# Altium schematic sheet [04] - MICROCONTROLLER.SchDoc (continued)

## Library data of the parts
AE1 = 2450AT45A100E: RF ANT 2.4GHZ CHIP SOLDER SMD; Manufacturer 1: Johanson; .PCBChecked: Alex Batista; Bandwidth: 100MHz; Frequency: 2.45GHz; Gain: 1dB; Impedance: 50R; Max Frequency: 2.5GHz; Max Power Dissipation: 3W; Number of Bands: 1; Return Loss: -9.5dB; Component Kind: Standard; ComponentLink1Description: https://datasheet.ciiva.com/1126/1296113-1126176.pdf?src-supplier=Newark; ComponentLink1URL: https://datasheet.ciiva.com/1126/1296113-1126176.pdf?src-supplier=Newark; ComponentLink2Description: https://datasheet.ciiva.com/2791/jti-antenna-2450at45a100-2006-07-2791936.pdf?src-supplier=Digi-Key; ComponentLink2URL: https://datasheet.ciiva.com/2791/jti-antenna-2450at45a100-2006-07-2791936.pdf?src-supplier=Digi-Key; ComponentLink3Description: https://datasheet.ciiva.com/6748/antenna-2450at45a100-v5-6748578.pdf?src-supplier=Newark; ComponentLink3URL: https://datasheet.ciiva.com/6748/antenna-2450at45a100-v5-6748578.pdf?src-supplier=Newark; ComponentLink4Description: https://datasheet.ciiva.com/24068/antenna-2450at45a100-v5-242465-24068002.pdf?src-supplier=Mouser; ComponentLink4URL: https://datasheet.ciiva.com/24068/antenna-2450at45a100-v5-242465-24068002.pdf?src-supplier=Mouser; ComponentLink5Description: https://datasheet.ciiva.com/2791/jti-antenna-2450at45a100-2006-07-2791937.pdf?src-supplier=Digi-Key; ComponentLink5URL: https://datasheet.ciiva.com/2791/jti-antenna-2450at45a100-2006-07-2791937.pdf?src-supplier=Digi-Key; ComponentLink6Description: https://datasheet.ciiva.com/2791/jti-antenna-2450at45a100-2006-07-2791938.pdf?src-supplier=Digi-Key; ComponentLink6URL: https://datasheet.ciiva.com/2791/jti-antenna-2450at45a100-2006-07-2791938.pdf?src-supplier=Digi-Key; ComponentLink7Description: https://datasheet.ciiva.com/1124/1318299-1124920.pdf?src-supplier=Element14; ComponentLink7URL: https://datasheet.ciiva.com/1124/1318299-1124920.pdf?src-supplier=Element14; Height: 0.047inch; Length: 9.5mm; Manufacturer Part Number 1: 2450AT45A100E; Max Operating Temperature: 85°C; Min Operating Temperature: -40°C; Mount: Surface Mount; Number of Pins: 2; Package Quantity: 1; Packaging: Tape and Reel; Power Rating: 3W; Pricing 1: 1=0.94000, 10=0.84100, 25=0.79800, 100=0.65550, 250=0.61276, 500=0.54150 (USD); Radiation Hardening: No; REACH SVHC: No SVHC; Resistor Type: Ceramic; RoHS: Yes; Stock 1: 275; Supplier 1: Digi-Key; Supplier Part Number 1: 712-1012-1-ND; Termination: Solder; Width: 2mm; .SCHChecked: Alex Batista; Library Name: fast_charger_slide_controller_P2.SchLib
C7 C8 C16 C18 C26 C27 = KGM05AR71C104KH: MLCC - 100nF 16V 0402 X7R ±10%.; Manufacturer 1: Kyocera AVX; .Mounting: SMT; .PCBChecked: Lucas Vieira; Category 1: Ceramic Capacitors; Description 1: Cap Cer 0.1UF 16V X7R 0402; Component Kind: Standard; Manufacturer 2: Murata; Manufacturer 3: Taiyo Yuden; Manufacturer Part Number 1: KGM05AR71C104KH; Manufacturer Part Number 2: GRM155R71E104KE14J; Manufacturer Part Number 3: EMK105B7104KV-F; Pricing 1: 1=0,1, 10=0,014, 50=0,008, 100=0,0062, 500=0,00468, 1000=0,00368, 2500=0,00335, 5000=0,00308 (USD); RoHS 1: No; Stock 1: 2329199; Supplier 1: Digikey; Supplier Part Number 1: 478-KGM05AR71C104KHCT-ND; .SCHChecked: Lucas Vieira; Library Name: fast_charger_slide_controller_P2.SchLib
C9 C14 = CL05X105KP5NNNC: MLCC - 1µF 10V 0402 X6R ±10%.; Manufacturer 1: Samsung; .Mounting: SMT; Capacitor Type: Ceramic; Multilayer: Yes; Temperature Characteristics Code: X6S; Tolerance  (fill in as +-): 10%; Value: 1uF; Case/Package: 0402; Category: Ceramic Capacitors; Component Kind: Standard; ComponentLink1Description: https://datasheet.ciiva.com:443/pdfs/VipMasterIC/IC/SEMS/SEMS-S-A0008522793/SEMS-S-A0008522935-1.pdf?src-supplier=IHS+Markit; ComponentLink1URL: https://datasheet.ciiva.com:443/pdfs/VipMasterIC/IC/SEMS/SEMS-S-A0008522793/SEMS-S-A0008522935-1.pdf?src-supplier=IHS+Markit; ComponentLink2Description: http://www.samsungsem.com/kr/support/library/product-catalog/mlcc.jsp?src-supplier=Digikey; ComponentLink2URL: http://www.samsungsem.com/kr/support/library/product-catalog/mlcc.jsp?src-supplier=Digikey; ComponentLink3Description: http://www.samsungsem.com/kr/support/product-search/mlcc/CL05X105KP5NNNC.jsp?src-supplier=Digikey; ComponentLink3URL: http://www.samsungsem.com/kr/support/product-search/mlcc/CL05X105KP5NNNC.jsp?src-supplier=Digikey; ComponentLink4Description: http://www.samsungsem.com/global/front/downloadcms.do?path=%2F%2Fglobal%2F%2Fsupport%2F%2Flibrary%2F%2Fproduct-catalog%2F%2F__icsFiles%2F%2Fafieldfile%2F%2F2014%2F%2F11%2F%2F13&fileName=MLCC.pdf&src-supplier=Avnet; ComponentLink4URL: http://www.samsungsem.com/global/front/downloadcms.do?path=%2F%2Fglobal%2F%2Fsupport%2F%2Flibrary%2F%2Fproduct-catalog%2F%2F__icsFiles%2F%2Fafieldfile%2F%2F2014%2F%2F11%2F%2F13&fileName=MLCC.pdf&src-supplier=Avnet; ComponentLink5Description: https://datasheet.octopart.com/CL05X105KP5NNNC-Samsung-Electro-Mechanics-datasheet-115064993.pdf?src-supplier=Future+Electronics; ComponentLink5URL: https://datasheet.octopart.com/CL05X105KP5NNNC-Samsung-Electro-Mechanics-datasheet-115064993.pdf?src-supplier=Future+Electronics; Dielectric Material: Ceramic; Height: 0.55mm; JESD-609 Code: e3; Length: 1mm; Manufacturer Part Number 1: CL05X105KP5NNNC; Max Operating Temperature: 105°C; Min Operating Temperature: -55°C; Mounting Technology: Surface Mount; Package Shape: Rectangular; Package Style: SMTMeter; Packaging: Tape and Reel; Pins: 2; Pricing: 10000=0,00801 (USD); Rated DC Voltage (URdc): 10V; Reach SVHC Compliant: Yes; RoHS: Yes; RoHS Compliant: Yes; Stock: 10000; Supplier 1: Avnet; Supplier Part Number 1: CL05X105KP5NNNC; Terminal Finish: Nickel; Termination: Wraparound; Tolerance (fill in as +-): 10%; Width: 0.5mm; Library Name: fast_charger_slide_controller_P2.SchLib
C10 = GRM155R71H473KE14D: MLCC - 47nF 50V 0402 X7R ±10%; Manufacturer 1: Murata; .Mounting: SMT; Capacitor Type: Ceramic; Multilayer: Yes; Case/Package: 0402; Category: Ceramic Capacitors; Component Kind: Standard; ComponentLink1Description: https://datasheet.ciiva.com:443/pdfs/VipMasterIC/IC/MURE/MURE-S-A0004362469/MURE-S-A0004364796-1.pdf?src-supplier=IHS+Markit; ComponentLink1URL: https://datasheet.ciiva.com:443/pdfs/VipMasterIC/IC/MURE/MURE-S-A0004362469/MURE-S-A0004364796-1.pdf?src-supplier=IHS+Markit; ComponentLink2Description: http://www.mouser.com/ds/2/281/c02e-2905.pdf?src-supplier=Mouser; ComponentLink2URL: http://www.mouser.com/ds/2/281/c02e-2905.pdf?src-supplier=Mouser; ComponentLink3Description: http://search.murata.co.jp/Ceramy/image/img/A01X/G101/ENG/GRM155R71H473KE14-01.pdf?src-supplier=Digikey; ComponentLink3URL: http://search.murata.co.jp/Ceramy/image/img/A01X/G101/ENG/GRM155R71H473KE14-01.pdf?src-supplier=Digikey; ComponentLink4Description: https://datasheet.octopart.com/GRM155R71H473KE14D-Murata-datasheet-32479577.pdf?src-supplier=Future+Electronics; ComponentLink4URL: https://datasheet.octopart.com/GRM155R71H473KE14D-Murata-datasheet-32479577.pdf?src-supplier=Future+Electronics; ComponentLink5Description: https://datasheet.octopart.com/GRM155R71H473KE14D-Murata-datasheet-149523079.pdf?src-supplier=Newark; ComponentLink5URL: https://datasheet.octopart.com/GRM155R71H473KE14D-Murata-datasheet-149523079.pdf?src-supplier=Newark; ComponentLink6Description: https://datasheet.octopart.com/GRM155R71H473KE14D-Murata-datasheet-150009149.pdf?src-supplier=Arrow.cn; ComponentLink6URL: https://datasheet.octopart.com/GRM155R71H473KE14D-Murata-datasheet-150009149.pdf?src-supplier=Arrow.cn; ComponentLink7Description: https://datasheet.octopart.com/GRM155R71H473KE14D-Murata-datasheet-165579666.pdf?src-supplier=TME; ComponentLink7URL: https://datasheet.octopart.com/GRM155R71H473KE14D-Murata-datasheet-165579666.pdf?src-supplier=TME; Dielectric Material: Ceramic; Height: 0.5mm; JESD-609 Code: e3; Length: 1mm; Manufacturer Part Number 1: GRM155R71H473KE14D; Max Operating Temperature: 125°C; Min Operating Temperature: -55°C; Mounting Technology: Surface Mount; Package Shape: Rectangular; Package Style: SMTMeter; Packaging: Tape and Reel; Pins: 2; Pricing: 10000=0,0031, 40000=0,0029, 150000=0,0028, 250000=0,0027, 500000=0,0025 (USD); Rated DC Voltage (URdc): 50V; Reach SVHC Compliant: Yes; RoHS: Yes; RoHS Compliant: Yes; Stock: 660000; Supplier 1: Future Electronics; Supplier Part Number 1: 7087193; Temperature Characteristics Code: X7R; Terminal Finish: Nickel; Termination: Wraparound; Tolerance  (fill in as +-): 10%; Tolerance (fill in as +-): 10%; Value: 47nF; Width: 0.5mm; Library Name: fast_charger_slide_controller_P2.SchLib
C11 = MLCC_0402: MLCC - TBD 0402.; Component Kind: Standard
C12 C24 = GRM21BR71C475KE51K: MLCC - 4.7µF 16V 0805 X7R ±10%.; Manufacturer 1: Murata; .Mounting: SMT; .PCBChecked: Lucas Vieira; Category 1: Ceramic Capacitors; Description 1: Cap 4.7UF 16VDC X7R 10% SMD 0805 Embossed Tape; Tolerance: 10%; Value: 4.7uF; Case/Package: 0805; Component Kind: Standard; ComponentLink1Description: https://search.murata.co.jp/Ceramy/image/img/A01X/G101/ENG/GRM21BR71C475KE51-01A.pdf?src-supplier=Digi-Key; ComponentLink1URL: https://search.murata.co.jp/Ceramy/image/img/A01X/G101/ENG/GRM21BR71C475KE51-01A.pdf?src-supplier=Digi-Key; Dielectric: X7R; Length: 2mm; Manufacturer 2: Murata; Manufacturer 3: Murata; Manufacturer Part Number 1: GRM21BR71C475KE51K; Manufacturer Part Number 2: GRM21BR71A475KE51K; Manufacturer Part Number 3: GRM21BR71A475KE51L; Pricing 1: 1=0,15, 10=0,109, 50=0,0616, 100=0,0511, 500=0,0358, 1000=0,02964, 2500=0,02759, 5000=0,02524 (USD); RoHS 1: Yes; RoHS Compliant: Yes; Stock 1: 90687; Supplier 1: Digi-Key; Supplier Part Number 1: 490-GRM21BR71C475KE51KCT-ND; Thickness: 1.25mm; Voltage Rating (DC): 16V; Width: 1.25mm; .SCHChecked: Lucas Vieira; Library Name: fast_charger_slide_controller_P2.SchLib
C13 = CC0402JRX7R9BB821: MLCC - 820pF 50V 0402 X7R ±5%; Manufacturer 1: Yageo; .Mounting: SMT; Capacitor Type: Ceramic; Multilayer: Yes; Case/Package: 0402; Category: Ceramic Capacitors; Component Kind: Standard; ComponentLink1Description: https://datasheet.ciiva.com:443/pdfs/VipMasterIC/IC/YAGO/YAGO-S-A0003557223/YAGO-S-A0003557223-1.pdf?src-supplier=IHS+Markit; ComponentLink1URL: https://datasheet.ciiva.com:443/pdfs/VipMasterIC/IC/YAGO/YAGO-S-A0003557223/YAGO-S-A0003557223-1.pdf?src-supplier=IHS+Markit; ComponentLink2Description: https://datasheet.ciiva.com/13263/upy-gphc-x7r-6-3v-to-50v-8-12304-13263692.pdf?src-supplier=Mouser; ComponentLink2URL: https://datasheet.ciiva.com/13263/upy-gphc-x7r-6-3v-to-50v-8-12304-13263692.pdf?src-supplier=Mouser; Dielectric Material: Ceramic; JESD-609 Code: e3; Manufacturer Part Number 1: CC0402JRX7R9BB821; Max Operating Temperature: 125°C; Min Operating Temperature: -55°C; Mounting Technology: Surface Mount; Package Shape: Rectangular; Packaging: Tape and Reel; Pins: 2; Pricing: 1=0,1, 10=0,037, 50=0,021, 100=0,0162, 500=0,01224, 1000=0,00961, 2500=0,00874, 5000=0,00804 (USD); Rated DC Voltage (URdc): 50V; Reach SVHC Compliant: Yes; RoHS: Yes; RoHS Compliant: Yes; Stock: 106880; Supplier 1: Digikey; Supplier Part Number 1: 311-3776-1-ND; Temperature Characteristics Code: X7R; Terminal Finish: Nickel; Termination: Wraparound; Tolerance  (fill in as +-): 5%; Tolerance (fill in as +-): 5%; Value: 820pF; Library Name: fast_charger_slide_controller_P2.SchLib
C15 C17 C19 C21 = CC0402JRNPO9BN120: MLCC - 12 pF 50V 0402 X7R ±5%.; Manufacturer 1: Yageo; .Mounting: SMT; .PCBChecked: Lucas Vieira; Category 1: Ceramic Capacitors; Description 1: Multilayer Ceramic Capacitor, 12 pF, 50 V, ± 5%, X7R, 0402 [1005 Metric]; Dielectric: C0G; Material: 4241ConductorMaterial; Temperature Coefficient: 30ppm/°C; Tolerance: 5%; Value: 12pF; Case Code (Imperial): 0402; Case Code (Metric): 1005; Case/Package: 0402; Component Kind: Standard; ComponentLink1Description: https://datasheet.ciiva.com/161/mlccorderingcode-161486.pdf?src-supplier=Digikey; ComponentLink1URL: https://datasheet.ciiva.com/161/mlccorderingcode-161486.pdf?src-supplier=Digikey; ComponentLink2Description: https://datasheet.ciiva.com/28450/1443161-28450222.pdf?src-supplier=Element14; ComponentLink2URL: https://datasheet.ciiva.com/28450/1443161-28450222.pdf?src-supplier=Element14; ComponentLink3Description: https://datasheet.ciiva.com/5425/upy-gp-np0-16v-to-50v-8-5425431.pdf?src-supplier=Mouser; ComponentLink3URL: https://datasheet.ciiva.com/5425/upy-gp-np0-16v-to-50v-8-5425431.pdf?src-supplier=Mouser; ComponentLink4Description: https://datasheet.ciiva.com/161/mlccorderingcode-161487.pdf?src-supplier=Digikey; ComponentLink4URL: https://datasheet.ciiva.com/161/mlccorderingcode-161487.pdf?src-supplier=Digikey; ComponentLink5Description: https://datasheet.ciiva.com/161/mlccorderingcode-161488.pdf?src-supplier=Digikey; ComponentLink5URL: https://datasheet.ciiva.com/161/mlccorderingcode-161488.pdf?src-supplier=Digikey; ComponentLink6Description: https://datasheet.ciiva.com/11587/upy-gp-np0-16v-to-50v-8-11587083.pdf?src-supplier=Avnet; ComponentLink6URL: https://datasheet.ciiva.com/11587/upy-gp-np0-16v-to-50v-8-11587083.pdf?src-supplier=Avnet; Depth: 0.5mm; Halogen Free: 5694HalogenFree; Height: 0.5mm; Lead Free: 5697LeadFree; Length: 1mm; Manufacturer 2: KYOCERA AVX; Manufacturer 3: KYOCERA AVX; Manufacturer Part Number 1: CC0402JRNPO9BN120; Manufacturer Part Number 2: KGM05ACG1H120JN; Manufacturer Part Number 3: KGM05ACG1H120JH; Max Operating Temperature: 125°C; Min Operating Temperature: -55°C; Mounting Technology: Surface Mount; Package Quantity: 1; Packaging: Tape and Reel; Pins: 2; Pricing 1: 1=0,1, 10=0,017, 50=0,01, 100=0,0077, 500=0,00584, 1000=0,00458, 2500=0,00417, 5000=0,00383 (USD); Radiation Hardening: No; Reach SVHC Compliant: No; RoHS 1: Yes; RoHS Compliant: Yes; Series: CC; Stock 1: 2131688; Supplier 1: Digikey; Supplier Part Number 1: 311-1016-1-ND; Termination: SMD/SMT; Thickness: 0.022inch; Voltage Rating: 50V; Voltage Rating (DC): 50V; Width: 0.02inch; .SCHChecked: Lucas Vieira; Library Name: fast_charger_slide_controller_P2.SchLib
C20 = CC0402JRNPO9BN101: MLCC - 100pF 50V 0402 NP0 ±5%.; Manufacturer 1: Yageo; .Mounting: SMT; .PCBChecked: Lucas Vieira; Category 1: Ceramic Capacitors; Description 1: 0402 100 pF 50 V ±5 % Tolerance NP0 SMT Multilayer Ceramic Capacitor; Dielectric: C0G; Dielectric Material: Ceramic; Insulation Resistance: 100GR; Temperature Coefficient: 30ppm/°C; Tolerance: 5%; Case Code (Imperial): 0402; Case Code (Metric): 1005; Case/Package: 0402; Component Kind: Standard; ComponentLink1Description: https://datasheet.ciiva.com/11786/0900766b80d84fbf-11786101.pdf?src-supplier=Rs; ComponentLink1URL: https://datasheet.ciiva.com/11786/0900766b80d84fbf-11786101.pdf?src-supplier=Rs; ComponentLink2Description: https://datasheet.ciiva.com/23767/25787-23767888.pdf?src-supplier=Newark; ComponentLink2URL: https://datasheet.ciiva.com/23767/25787-23767888.pdf?src-supplier=Newark; ComponentLink3Description: https://datasheet.ciiva.com/28450/1443161-28450220.pdf?src-supplier=Element14; ComponentLink3URL: https://datasheet.ciiva.com/28450/1443161-28450220.pdf?src-supplier=Element14; ComponentLink4Description: https://datasheet.ciiva.com/8033/1443161-8033598.pdf?src-supplier=Element14; ComponentLink4URL: https://datasheet.ciiva.com/8033/1443161-8033598.pdf?src-supplier=Element14; ComponentLink5Description: https://datasheet.ciiva.com/143/mlccorderingcode-143990.pdf?src-supplier=Digikey; ComponentLink5URL: https://datasheet.ciiva.com/143/mlccorderingcode-143990.pdf?src-supplier=Digikey; ComponentLink6Description: https://datasheet.ciiva.com/143/mlccorderingcode-143989.pdf?src-supplier=Digikey; ComponentLink6URL: https://datasheet.ciiva.com/143/mlccorderingcode-143989.pdf?src-supplier=Digikey; ComponentLink7Description: https://datasheet.ciiva.com/143/mlccorderingcode-143991.pdf?src-supplier=Digikey; ComponentLink7URL: https://datasheet.ciiva.com/143/mlccorderingcode-143991.pdf?src-supplier=Digikey; Depth: 0.5mm; Height: 0.5mm; Lead Free: Lead Free; Length: 1mm; Manufacturer 2: Murata; Manufacturer 3: Murata; Manufacturer Part Number 1: CC0402JRNPO9BN101; Manufacturer Part Number 2: GRM1555C1H101JA01D; Manufacturer Part Number 3: GCM1555C1H101JA16D; Max Operating Temperature: 125°C; Min Operating Temperature: -55°C; Mounting Technology: Surface Mount; Package Quantity: 1; Packaging: Tape and Reel; Pins: 2; Pricing 1: 1=0,1, 10=0,017, 50=0,0096, 100=0,0074, 500=0,00554, 1000=0,00436, 2500=0,00396, 5000=0,00365 (USD); Radiation Hardening: No; Reach SVHC Compliant: No; RoHS 1: Yes; RoHS Compliant: Yes; Series: CC; Stock 1: 10509796; Supplier 1: Digikey; Supplier Part Number 1: 311-1024-1-ND; Termination: SMD/SMT; Thickness: 0.022inch; Value: 100pF; Voltage: 50V; Voltage Rating: 50V; Voltage Rating (DC): 50V; Width: 0.02inch; .SCHChecked: Lucas Vieira; Library Name: fast_charger_slide_controller_P2.SchLib
C22 = GJM1555C1H1R0WB01D: MLCC - 1pF 50V 0402 NP0 ±5%.; Manufacturer 1: Murata; .Mounting: SMT; Capacitor Type: Ceramic; Multilayer: Yes; Temperature Characteristics Code: C0G; Tolerance  (fill in as +-): 5%; Case/Package: 0402; Category: Ceramic Capacitors; Component Kind: Standard; ComponentLink1Description: https://datasheet.ciiva.com:443/pdfs/VipMasterIC/IC/MURE/MURE-S-A0004378008/MURE-S-A0004383032-1.pdf?src-supplier=IHS+Markit; ComponentLink1URL: https://datasheet.ciiva.com:443/pdfs/VipMasterIC/IC/MURE/MURE-S-A0004378008/MURE-S-A0004383032-1.pdf?src-supplier=IHS+Markit; ComponentLink2Description: https://datasheet.ciiva.com/11616/product-11616304.pdf?src-supplier=Avnet; ComponentLink2URL: https://datasheet.ciiva.com/11616/product-11616304.pdf?src-supplier=Avnet; Dielectric Material: Ceramic; Height: 0.5mm; JESD-609 Code: e3; Length: 1mm; Manufacturer Part Number 1: GJM1555C1H1R0WB01D; Max Operating Temperature: 125°C; Min Operating Temperature: -55°C; Mounting Technology: Surface Mount; Package Shape: Rectangular; Package Style: SMTMeter; Packaging: Tape and Reel; Pins: 2; Pricing: 10000=0,0193, 20000=0,0189, 40000=0,0185, 50000=0,0183, 150000=0,0175 (USD); Rated DC Voltage (URdc): 50V; Reach SVHC Compliant: Yes; RoHS: Yes; RoHS Compliant: Yes; Stock: 100000; Supplier 1: Future Electronics; Supplier Part Number 1: 9074054; Terminal Finish: Nickel; Termination: Wraparound; Tolerance (fill in as +-): 5%; Value: 1pF; Width: 0.5mm; Library Name: fast_charger_slide_controller_P2.SchLib
C23 = GJM1555C1H1R2WB01J: MLCC - 1.2pF 50V 0402 NP0 ±4.17%; Manufacturer 1: Murata; .Mounting: SMT; Capacitor Type: Ceramic; Multilayer: Yes; Temperature Characteristics Code: C0G; Case/Package: 0402; Category: Ceramic Capacitors; Component Kind: Standard; ComponentLink1Description: https://datasheet.ciiva.com:443/pdfs/VipMasterIC/IC/MURE/MURE-S-A0004378008/MURE-S-A0004382814-1.pdf?src-supplier=IHS+Markit; ComponentLink1URL: https://datasheet.ciiva.com:443/pdfs/VipMasterIC/IC/MURE/MURE-S-A0004378008/MURE-S-A0004382814-1.pdf?src-supplier=IHS+Markit; ComponentLink2Description: http://www.mouser.com/ds/2/281/Murata%20GJM%20Series-1149669.pdf?src-supplier=Mouser; ComponentLink2URL: http://www.mouser.com/ds/2/281/Murata%20GJM%20Series-1149669.pdf?src-supplier=Mouser; ComponentLink3Description: https://datasheet.octopart.com/GJM1555C1H1R2WB01J-Murata-datasheet-155238911.pdf?src-supplier=Farnell; ComponentLink3URL: https://datasheet.octopart.com/GJM1555C1H1R2WB01J-Murata-datasheet-155238911.pdf?src-supplier=Farnell; ComponentLink4Description: http://search.murata.co.jp/Ceramy/image/img/PDF/ENG/GJM1555C1H1R2WB01.pdf?src-supplier=Avnet; ComponentLink4URL: http://search.murata.co.jp/Ceramy/image/img/PDF/ENG/GJM1555C1H1R2WB01.pdf?src-supplier=Avnet; Dielectric Material: Ceramic; Height: 0.5mm; JESD-609 Code: e3; Length: 1mm; Manufacturer Part Number 1: GJM1555C1H1R2WB01J; Max Operating Temperature: 125°C; Min Operating Temperature: -55°C; Mounting Technology: Surface Mount; Package Shape: Rectangular; Package Style: SMTMeter; Packaging: Tape and Reel; Pins: 2; Pricing: 1=0,17, 10=0,073, 50=0,073, 100=0,043, 1000=0,032, 10000=0,025 (USD); Rated DC Voltage (URdc): 50V; Reach SVHC Compliant: Yes; RoHS: Yes; RoHS Compliant: Yes; Stock: 18280; Supplier 1: Mouser; Supplier Part Number 1: 81-GJM1555C1H1R2WB1J; Terminal Finish: Nickel; Termination: Wraparound; Tolerance  (fill in as +-): 4.17%; Tolerance (fill in as +-): 4.17%; Value: 1.2pF; Width: 0.5mm; Library Name: fast_charger_slide_controller_P2.SchLib
D3 = KW DELPS2.RA-MIPI-FK1NN1-S4W5-0.5-R18: DIODE - LED WHITE DIFFUSED 2.625V 0.5mA; Manufacturer 1: ams-osram; .Mounting: SMT; Category: LEDS; Component Kind: Standard; Manufacturer Part Number 1: KW DELPS2.RA-MIPI-FK1NN1-S4W5-0.5-R18; Supplier: Digikey; Library Name: fast_charger_slide_controller_P2.SchLib
L1 = BSCH0010050515NJCS: IND - 15nH 100MHz 300mA 0402 ±5%.; Manufacturer 1: Pulse; .Mounting: SMT; .PCBChecked: Lucas Vieira; Category 1: Fixed Inductors; Description 1: Inductor Chip Unshielded Multilayer 15nH 5% 100MHz 8Q-Factor Ceramic 300mA 0402 T/R; Component Kind: Standard; Manufacturer 2: Pulse; Manufacturer 3: Pulse; Manufacturer Part Number 1: BSCH0010050515NJCS; Manufacturer Part Number 2: BSCH0010050515NKCS; Manufacturer Part Number 3: BSCH0010050515NJCP; Pricing 1: 1=0,1, 10=0,028, 25=0,0268, 50=0,0226, 100=0,0192, 250=0,01832, 500=0,01666, 1000=0,014, 2500=0,012 (USD); RoHS 1: No; Stock 1: 175439; Supplier 1: Digikey; Supplier Part Number 1: 553-BSCH0010050515NJCSCT-ND; .SCHChecked: Lucas Vieira; Library Name: fast_charger_slide_controller_P2.SchLib
L2 = IND_0402: IND - TBD 0402.; Component Kind: Standard; Library Name: fast_charger_slide_controller.SchLib
L3 = MLZ1608M100WT000: IND - 10µH 2MHz 0.09A 1.365Ohm 0603 ±20%.; Manufacturer 1: TDK; .Mounting: SMT; .PCBChecked: Lucas Vieira; Category 1: Fixed Inductors; Core Material: Ferrite; Current Rating: 250mA; DC Resistance (DCR): 1.365R; Description 1: Inductor Power Shielded Multi-Layer 10uH 20% 2MHz Ferrite 0.09A 1.365Ohm DCR 0603 T/R; ESR: 1.05R; Saturation Current: 90mA; Self Resonant Frequency: 50MHz; Shielding: Shielded; Tolerance: 20%; Value: 1.05R; Case Code (Imperial): 0603; Case Code (Metric): 1608; Case/Package: 0603; Component Kind: Standard; ComponentLink1Description: https://product.tdk.com/info/en/catalog/datasheets/inductor_commercial_decoupling_mlz1608_en.pdf?src-supplier=Digikey; ComponentLink1URL: https://product.tdk.com/info/en/catalog/datasheets/inductor_commercial_decoupling_mlz1608_en.pdf?src-supplier=Digikey; ComponentLink2Description: https://datasheet.octopart.com/MLZ1608M100WT000-TDK-datasheet-12356712.pdf?src-supplier=Newark; ComponentLink2URL: https://datasheet.octopart.com/MLZ1608M100WT000-TDK-datasheet-12356712.pdf?src-supplier=Newark; ComponentLink3Description: https://datasheet.octopart.com/MLZ1608M100WT000-TDK-datasheet-38159369.pdf?src-supplier=Future+Electronics; ComponentLink3URL: https://datasheet.octopart.com/MLZ1608M100WT000-TDK-datasheet-38159369.pdf?src-supplier=Future+Electronics; Height: 950um; Height - Seated (Max): 0.037inch; Length: 0.063inch; Manufacturer 2: TDK; Manufacturer 3: Murata; Manufacturer Part Number 1: MLZ1608M100WT000; Manufacturer Part Number 2: MLZ1608N100LT000; Manufacturer Part Number 3: LQM18DN100M70L; Max Operating Temperature: 125°C; Min Operating Temperature: -55°C; Mounting Technology: Surface Mount; Package Quantity: 4000; Packaging: Cut Tape; Pricing 1: 1=0,14, 10=0,114, 25=0,1052, 50=0,0928, 100=0,0811, 250=0,07724, 500=0,07262, 1000=0,05562 (USD); RMS Current (Irms): 250mA; RoHS 1: Yes; RoHS Compliant: Yes; Series: MLZ; Stock 1: 315981; Supplier 1: Digikey; Supplier Part Number 1: 445-6389-1-ND; Test Frequency: 2MHz; Width: 0.031inch; .SCHChecked: Lucas Vieira; Library Name: fast_charger_slide_controller_P2.SchLib
L4 = LRC0402CS4N7GV001T: IND - 4.7nH 100MHz 300mA 0402 6.38%; Manufacturer 1: Johanson; .Mounting: SMT; Category: Fixed Inductors; Component Kind: Standard; ComponentLink1Description: https://datasheet.octopart.com/LRC0402CS4N7GV001T-Johanson-datasheet-98397494.pdf?src-supplier=RFMW; ComponentLink1URL: https://datasheet.octopart.com/LRC0402CS4N7GV001T-Johanson-datasheet-98397494.pdf?src-supplier=RFMW; Manufacturer Part Number 1: LRC0402CS4N7GV001T; Pricing: 1=0,1, 10=0,041, 25=0,0384, 50=0,0326, 100=0,0276, 250=0,0264, 500=0,02398, 1000=0,02015, 2500=0,01727 (USD); Reach SVHC Compliant: No; RoHS: Yes; RoHS Compliant: Yes; Stock: 127873; Supplier 1: Digikey; Supplier Part Number 1: 712-LRC0402CS4N7GV001TCT-ND; Library Name: fast_charger_slide_controller_P2.SchLib
L5 = MLG1005S2N2BT000: IND - 2.2nH 100MHz 900mA 0402 4.5%; Manufacturer 1: TDK; .Mounting: SMT; Case/Size Code: 1005; Channels: 1; Core Material: Ferrite; DC Resistance (DCR): 150mR; Inductance-Nom (L): 0.0022uH; Inductor Application: RF INDUCTOR; Inductor Type: GENERAL PURPOSE INDUCTOR; Package Height: 0.5mm; Package Length: 1mm; Quality Factor-Min (at L-nom): 7; Self Resonant Frequency: 7GHz; Shape/Size Description: RECTANGULAR PACKAGE; Shielding: Unshielded; Tolerance: 4.546%; Category: Fixed Inductors; Component Kind: Standard; ComponentLink1Description: https://datasheet.ciiva.com:443/pdfs/VipMasterIC/IC/TDKC/TDKC-S-A0007110064/TDKC-S-A0007110064-1.pdf?src-supplier=IHS+Markit; ComponentLink1URL: https://datasheet.ciiva.com:443/pdfs/VipMasterIC/IC/TDKC/TDKC-S-A0007110064/TDKC-S-A0007110064-1.pdf?src-supplier=IHS+Markit; ComponentLink2Description: https://datasheet.ciiva.com/29696/inductor-commercial-high-frequency-mlg-en-29696230.pdf?src-supplier=Digikey; ComponentLink2URL: https://datasheet.ciiva.com/29696/inductor-commercial-high-frequency-mlg-en-29696230.pdf?src-supplier=Digikey; ComponentLink3Description: https://datasheet.ciiva.com/29696/inductor-commercial-high-frequency-mlg-en-29696231.pdf?src-supplier=Digikey; ComponentLink3URL: https://datasheet.ciiva.com/29696/inductor-commercial-high-frequency-mlg-en-29696231.pdf?src-supplier=Digikey; Construction: Multilayer Chip; JESD-609 Code: e3; Manufacturer Part Number 1: MLG1005S2N2BT000; Max Current Rating: 900mA; Max Operating Temperature: 125°C; Min Operating Temperature: -55°C; Package Style: SMTMeter; Package Width: 0.5mm; Packaging: Tape and Reel; Pins: 2; Pricing: 10000=0,0372, 20000=0,0363, 30000=0,0357, 40000=0,0354, 50000=0,0343 (USD); Reach SVHC Compliant: Yes; RoHS: Yes; RoHS Compliant: Yes; Series: MLG1005S; Stock: 10000; Supplier 1: Future Electronics; Supplier Part Number 1: 5073984; Surface Mount: Yes; Terminal Finish: Tin; Terminal Placement: Dual Ended; Termination: Wraparound; Test Frequency: 100MHz; Library Name: fast_charger_slide_controller_P2.SchLib
P2 = TC2030-CTX-NL: 6 pin Tag-Connect; .PCBChecked: Alex Batista; .SCHChecked: Alex Batista; Library Name: fast_charger_slide_controller.SchLib
Q2 = 2N7002K-7: TRANS - MOSFET N-Channel 60V 0.3A SOT-23.; Manufacturer 1: Diodes; .Mounting: SMT; Additional Feature: HIGH RELIABILITY; Drain Current-Max (Abs) (ID): 300mA; Drain-source On Resistance-Max: 2R; Feedback Cap-Max (Crss): 5pF; FET Technology: METAL-OXIDE SEMICONDUCTOR; Number of Elements: 1; Operating Mode: ENHANCEMENT MODE; Package Body Material: Plastic; Power Dissipation-Max (Abs): 350mW; Qualification Status: Not Qualified; Time @ Peak Reflow Temperature-Max (s): 40s; Transistor Application: SWITCHING; Transistor Element Material: SILICON; Category: MOSFETs; Component Kind: Standard; ComponentLink1Description: https://datasheet.ciiva.com:443/pdfs/VipMasterIC/IC/DIOD/DIOD-S-A0009689736/DIOD-S-A0009691901-1.pdf?src-supplier=IHS+Markit; ComponentLink1URL: https://datasheet.ciiva.com:443/pdfs/VipMasterIC/IC/DIOD/DIOD-S-A0009689736/DIOD-S-A0009691901-1.pdf?src-supplier=IHS+Markit; ComponentLink2Description: https://datasheet.ciiva.com/1254/ds30896-1254496.pdf?src-supplier=Newark; ComponentLink2URL: https://datasheet.ciiva.com/1254/ds30896-1254496.pdf?src-supplier=Newark; ComponentLink3Description: https://datasheet.ciiva.com/25624/0900766b81151e8c-25624936.pdf?src-supplier=Rs; ComponentLink3URL: https://datasheet.ciiva.com/25624/0900766b81151e8c-25624936.pdf?src-supplier=Rs; ComponentLink4Description: https://datasheet.ciiva.com/4444/ds30896-81375-4444887.pdf?src-supplier=Mouser; ComponentLink4URL: https://datasheet.ciiva.com/4444/ds30896-81375-4444887.pdf?src-supplier=Mouser; ComponentLink5Description: https://datasheet.ciiva.com/15137/getdatasheetpartid-75159-15137378.pdf?src-supplier=Verical; ComponentLink5URL: https://datasheet.ciiva.com/15137/getdatasheetpartid-75159-15137378.pdf?src-supplier=Verical; ComponentLink6Description: https://datasheet.ciiva.com/48532/ds30896-48532645.pdf?src-supplier=Avnet; ComponentLink6URL: https://datasheet.ciiva.com/48532/ds30896-48532645.pdf?src-supplier=Avnet; ComponentLink7Description: https://datasheet.ciiva.com/1188/ds30896-1188422.pdf?src-supplier=Element14; ComponentLink7URL: https://datasheet.ciiva.com/1188/ds30896-1188422.pdf?src-supplier=Element14; ComponentLink8Description: https://datasheet.ciiva.com/16200/ds30896-16200842.pdf?src-supplier=Future+Electronics; ComponentLink8URL: https://datasheet.ciiva.com/16200/ds30896-16200842.pdf?src-supplier=Future+Electronics; Drain Current-Max (ID): 300mA; DS Breakdown Voltage-Min: 60V; JESD-30 Code: R-PDSO-G3; JESD-609 Code: e3; Manufacturer Part Number 1: 2N7002K-7; Max Operating Temperature: 150°C; Moisture Sensitivity Level: 1; Package Shape: Rectangular; Package Style: SMALL OUTLINEMeter; Peak Reflow Temperature (Cel): 260°C; Pins: 3; Polarity/Channel Type: N-CHANNEL; Pricing: 3000=0,0186, 9000=0,0179, 30000=0,0172, 60000=0,0168, 120000=0,0161 (USD); Reach SVHC Compliant: Yes; RoHS: Yes; RoHS Compliant: Yes; Stock: 2232000; Supplier 1: Future Electronics; Supplier Part Number 1: 8672685; Surface Mount: Yes; Terminal Finish: Tin; Terminal Form: Gull Wing; Terminal Position: DUAL; Library Name: fast_charger_slide_controller_P2.SchLib
R7 R10 R12 = WR04X000PTL: RES - 0R  1/16W 0402 ±1%; Manufacturer 1: Walsin Technologies; .Mounting: SMT; Package Height: 0.35mm; Package Length: 1mm; Package Width: 0.5mm; Power: 62.5mW; Rated Temperature: 70°C; Case/Package: 0402; Category: Chip SMD Resistors; Component Kind: Standard; ComponentLink1Description: https://datasheet.ciiva.com:443/pdfs/VipMasterIC/IC/WATC/WATC-S-A0005256878/WATC-S-A0005256878-1.pdf?src-supplier=IHS+Markit; ComponentLink1URL: https://datasheet.ciiva.com:443/pdfs/VipMasterIC/IC/WATC/WATC-S-A0005256878/WATC-S-A0005256878-1.pdf?src-supplier=IHS+Markit; ComponentLink2Description: http://www.farnell.com/datasheets/1960319.pdf?src-supplier=Farnell; ComponentLink2URL: http://www.farnell.com/datasheets/1960319.pdf?src-supplier=Farnell; ComponentLink3Description: http://www.mouser.com/ds/2/210/WR-966.pdf?src-supplier=Mouser; ComponentLink3URL: http://www.mouser.com/ds/2/210/WR-966.pdf?src-supplier=Mouser; ComponentLink4Description: https://www.verical.com/server-webapp/html/getDatasheet?partID=413934&src-supplier=Verical; ComponentLink4URL: https://www.verical.com/server-webapp/html/getDatasheet?partID=413934&src-supplier=Verical; ComponentLink5Description: http://www.farnell.com/datasheets/1960319.pdf?src-supplier=Newark; ComponentLink5URL: http://www.farnell.com/datasheets/1960319.pdf?src-supplier=Newark; ComponentLink6Description: https://datasheet.octopart.com/WR04X000-PTL-Walsin-Technologies-datasheet-128065753.pdf?src-supplier=Future+Electronics; ComponentLink6URL: https://datasheet.octopart.com/WR04X000-PTL-Walsin-Technologies-datasheet-128065753.pdf?src-supplier=Future+Electronics; ComponentLink7Description: https://datasheet.octopart.com/WR04X000-PTL-Walsin-Technologies-datasheet-48038251.pdf?src-supplier=Element14; ComponentLink7URL: https://datasheet.octopart.com/WR04X000-PTL-Walsin-Technologies-datasheet-48038251.pdf?src-supplier=Element14; Construction: Chip; JESD-609 Code: e3; Manufacturer Part Number 1: WR04X000PTL; Max Operating Temperature: 155°C; Min Operating Temperature: -55°C; Mounting Technology: Surface Mount; Package Shape: Rectangular; Package Style: SMTMeter; Packaging: Tape and Reel; Pins: 2; Pricing: 1=0,1, 10=0,01, 25=0,0044, 50=0,0032, 100=0,0023, 250=0,0018, 500=0,00146, 1000=0,00107, 5000=0,00077 (USD); Reach SVHC Compliant: Yes; Resistor Type: FIXED RESISTOR; RoHS: Yes; RoHS Compliant: Yes; Stock: 4079657; Supplier 1: Digikey; Supplier Part Number 1: 1292-WR04X000PTLCT-ND; Technology: METAL GLAZE/THICK FILM; Terminal Finish: Nickel; Termination: Wraparound; Value: 0R; Library Name: fast_charger_slide_controller_P2.SchLib
R8 = RC0402JR-071K5L: RES - 1.5K  1/16W 0402 ±5%; Manufacturer 1: Yageo; .Mounting: SMT; Additional Feature: LASER TRIMMABLE; Package Height: 0.35mm; Package Length: 1mm; Package Width: 0.5mm; Power: 62.5mW; Rated Temperature: 70°C; Temperature Coefficient: 100ppm/°C; Value: 1.5kR; Working Voltage: 50V; Case/Package: 0402; Category: Chip SMD Resistors; Component Kind: Standard; ComponentLink1Description: https://datasheet.ciiva.com:443/pdfs/VipMasterIC/IC/YAGO/YAGO-S-A0008145938/YAGO-S-A0008145938-1.pdf?src-supplier=IHS+Markit; ComponentLink1URL: https://datasheet.ciiva.com:443/pdfs/VipMasterIC/IC/YAGO/YAGO-S-A0008145938/YAGO-S-A0008145938-1.pdf?src-supplier=IHS+Markit; ComponentLink2Description: https://datasheet.ciiva.com/7850/1018594-7850166.pdf?src-supplier=Element14; ComponentLink2URL: https://datasheet.ciiva.com/7850/1018594-7850166.pdf?src-supplier=Element14; ComponentLink3Description: https://datasheet.ciiva.com/7850/1018594-7850167.pdf?src-supplier=Element14; ComponentLink3URL: https://datasheet.ciiva.com/7850/1018594-7850167.pdf?src-supplier=Element14; Construction: Chip; JESD-609 Code: e3; Manufacturer Part Number 1: RC0402JR-071K5L; Max Operating Temperature: 155°C; Min Operating Temperature: -55°C; Mounting Technology: Surface Mount; Package Shape: Rectangular; Package Style: SMTMeter; Packaging: Tape and Reel; Pins: 2; Pricing: 10000=0,0008, 100000=0,0007, 300000=0,0007, 750000=0,0007, 2000000=0,0006 (USD); Reach SVHC Compliant: Yes; Resistor Type: FIXED RESISTOR; RoHS: Yes; RoHS Compliant: Yes; Stock: 1010000; Supplier 1: Future Electronics; Supplier Part Number 1: 3475696; Technology: METAL GLAZE/THICK FILM; Terminal Finish: Nickel; Termination: Wraparound; Tolerance: 5%; Library Name: fast_charger_slide_controller_P2.SchLib
R9 = RC0402JR-071K2L: RES - 1.2K  1/16W 0402 ±5%; Manufacturer 1: Yageo; .Mounting: SMT; Additional Feature: LASER TRIMMABLE; Package Height: 0.35mm; Package Length: 1mm; Package Width: 0.5mm; Power: 62.5mW; Rated Temperature: 70°C; Temperature Coefficient: 100ppm/°C; Value: 1.2kR; Working Voltage: 50V; Case/Package: 0402; Category: Chip SMD Resistors; Component Kind: Standard; ComponentLink1Description: https://datasheet.ciiva.com:443/pdfs/VipMasterIC/IC/YAGO/YAGO-S-A0008145938/YAGO-S-A0008145938-1.pdf?src-supplier=IHS+Markit; ComponentLink1URL: https://datasheet.ciiva.com:443/pdfs/VipMasterIC/IC/YAGO/YAGO-S-A0008145938/YAGO-S-A0008145938-1.pdf?src-supplier=IHS+Markit; ComponentLink2Description: https://datasheet.ciiva.com/17068/pyu-rc0402-51-rohs-l-6-r-17068326.pdf?src-supplier=Future+Electronics; ComponentLink2URL: https://datasheet.ciiva.com/17068/pyu-rc0402-51-rohs-l-6-r-17068326.pdf?src-supplier=Future+Electronics; ComponentLink3Description: https://datasheet.ciiva.com/12558/pyu-rc0402-51-rohs-l-6-r-8721-12558349.pdf?src-supplier=Mouser; ComponentLink3URL: https://datasheet.ciiva.com/12558/pyu-rc0402-51-rohs-l-6-r-8721-12558349.pdf?src-supplier=Mouser; ComponentLink4Description: https://datasheet.ciiva.com/15189/getdatasheetpartid-325064-15189548.pdf?src-supplier=Verical; ComponentLink4URL: https://datasheet.ciiva.com/15189/getdatasheetpartid-325064-15189548.pdf?src-supplier=Verical; ComponentLink5Description: https://datasheet.ciiva.com/7850/1018594-7850165.pdf?src-supplier=Element14; ComponentLink5URL: https://datasheet.ciiva.com/7850/1018594-7850165.pdf?src-supplier=Element14; ComponentLink6Description: https://datasheet.ciiva.com/7983/1018594-7983557.pdf?src-supplier=Element14; ComponentLink6URL: https://datasheet.ciiva.com/7983/1018594-7983557.pdf?src-supplier=Element14; Construction: Chip; JESD-609 Code: e3; Manufacturer Part Number 1: RC0402JR-071K2L; Max Operating Temperature: 155°C; Min Operating Temperature: -55°C; Mounting Technology: Surface Mount; Package Shape: Rectangular; Package Style: SMTMeter; Packaging: Tape and Reel; Pins: 2; Pricing: 10000=0,0009, 100000=0,0008, 300000=0,0008, 750000=0,0008, 2000000=0,0007 (USD); Reach SVHC Compliant: Yes; Resistor Type: FIXED RESISTOR; RoHS: Yes; RoHS Compliant: Yes; Stock: 370000; Supplier 1: Future Electronics; Supplier Part Number 1: 2145555; Technology: METAL GLAZE/THICK FILM; Terminal Finish: Nickel; Termination: Wraparound; Tolerance: 5%; Library Name: fast_charger_slide_controller_P2.SchLib
R11 = RK73B1ETTP103J: RES - 10K 1/10W 0402 ±5%; Manufacturer 1: KOA Speer; .Mounting: SMT; Package Height: 0.35mm; Package Length: 1mm; Package Width: 0.5mm; Power: 100mW; Rated Temperature: 70°C; Reference Standard: AEC-Q200; Temperature Coefficient: 200ppm/°C; Value: 10kR; Working Voltage: 50V; Case/Package: 0402; Category: Chip SMD Resistors; Component Kind: Standard; ComponentLink1Description: https://datasheet.ciiva.com:443/pdfs/VipMasterIC/IC/KOAS/KOAS-S-A0009586303/KOAS-S-A0009586303-1.pdf?src-supplier=IHS+Markit; ComponentLink1URL: https://datasheet.ciiva.com:443/pdfs/VipMasterIC/IC/KOAS/KOAS-S-A0009586303/KOAS-S-A0009586303-1.pdf?src-supplier=IHS+Markit; ComponentLink2Description: https://datasheet.ciiva.com/15159/getdatasheetpartid-89073-15159879.pdf?src-supplier=Verical; ComponentLink2URL: https://datasheet.ciiva.com/15159/getdatasheetpartid-89073-15159879.pdf?src-supplier=Verical; Construction: Rectangular; JESD-609 Code: e3; Manufacturer Part Number 1: RK73B1ETTP103J; Max Operating Temperature: 155°C; Min Operating Temperature: -55°C; Mounting Technology: Surface Mount; Package Style: SMTMeter; Packaging: Tape and Reel; Pins: 2; Pricing: 1=0,1, 10=0,017, 50=0,0104, 100=0,0087, 500=0,0058, 1000=0,00494, 5000=0,00355 (USD); Reach SVHC Compliant: Yes; Resistor Type: FIXED RESISTOR; RoHS: Yes; RoHS Compliant: Yes; Stock: 1386278; Supplier 1: Digikey; Supplier Part Number 1: 2019-RK73B1ETTP103JCT-ND; Technology: METAL GLAZE/THICK FILM; Terminal Finish: Nickel; Termination: Wraparound; Tolerance: 5%; Library Name: fast_charger_slide_controller_P2.SchLib
SW2 SW3 = D2F-01FL: SWITCH - SNAP ACT SPDT 0.1A 30V; Manufacturer 1: Omron; .Mounting: PTH; Actuator Travel: 0.55mm; Actuator Type: HINGE LEVER; Body Breadth: 5.8inch; Body Height: 6.5mm; Body Length or Diameter: 12.8mm; Contact Current(DC)-Max: 100mA; Contact DC Max Rating R Load: .1A@30VDC; Electrical Life: 30000Cycles; Operating Force-Max: 0.74N; Sealing: IP40; Switch Action: MOMENTARY; Switch Type: Snap Acting/Limit; Category: Snap Action / Limit Switches; Component Kind: Standard; ComponentLink1Description: https://datasheet.ciiva.com:443/pdfs/VipMasterIC/IC/OMRN/OMRN-S-A0002892239/OMRN-S-A0002892239-1.pdf?src-supplier=IHS+Markit; ComponentLink1URL: https://datasheet.ciiva.com:443/pdfs/VipMasterIC/IC/OMRN/OMRN-S-A0002892239/OMRN-S-A0002892239-1.pdf?src-supplier=IHS+Markit; ComponentLink2Description: https://datasheet.ciiva.com/6944/en-d2f-6944006.pdf?src-supplier=Newark; ComponentLink2URL: https://datasheet.ciiva.com/6944/en-d2f-6944006.pdf?src-supplier=Newark; ComponentLink3Description: https://datasheet.ciiva.com/1530/en-d2f-1530497.pdf?src-supplier=Element14; ComponentLink3URL: https://datasheet.ciiva.com/1530/en-d2f-1530497.pdf?src-supplier=Element14; ComponentLink4Description: https://datasheet.ciiva.com/32417/d2f-32417544.pdf?src-supplier=Distrelec; ComponentLink4URL: https://datasheet.ciiva.com/32417/d2f-32417544.pdf?src-supplier=Distrelec; ComponentLink5Description: https://datasheet.ciiva.com/2891/d2f-1113-2891478.pdf?src-supplier=Digikey; ComponentLink5URL: https://datasheet.ciiva.com/2891/d2f-1113-2891478.pdf?src-supplier=Digikey; ComponentLink6Description: https://datasheet.ciiva.com/16176/d2f-1110-16176573.pdf?src-supplier=Onlinecomponents.com; ComponentLink6URL: https://datasheet.ciiva.com/16176/d2f-1110-16176573.pdf?src-supplier=Onlinecomponents.com; ComponentLink7Description: https://datasheet.ciiva.com/30140/en-d2f-30140302.pdf?src-supplier=Onlinecomponents.com; ComponentLink7URL: https://datasheet.ciiva.com/30140/en-d2f-30140302.pdf?src-supplier=Onlinecomponents.com; Contact Voltage(DC)-Max: 30V; Manufacturer Part Number 1: D2F-01FL; Max Operating Temperature: 65°C; Min Operating Temperature: -25°C; Mounting Technology: Through Hole; Pricing: 1=0,8312, 10=0,8076, 25=0,7995, 50=0,7914, 100=0,7325, 250=0,6576 (USD); RoHS: Yes; RoHS Compliant: Yes; Stock: 14887; Supplier 1: Arrow Electronics; Supplier Part Number 1: D2F-01FL; Switch Function: SPDT; Termination: Solder; Library Name: fast_charger_slide_controller_P2.SchLib
TP7-TP11 = Test_Point: MECH - Test Points
  TP7-TP9: Library Name: fast_charger_slide_controller.SchLib
  TP10 TP11: Library Name: fast_charger_slide_controller_P1_Rangel_refactored.SchLib
U4 = NRF52820-QDAA-R: IC - Nordic ANT and 2.5GHz proprietary system-on-chip; Manufacturer 1: Nordic Semiconductor; .Mounting: SMT; Category: RF Receivers, Transceivers; Component Kind: Standard; ComponentLink1Description: https://infocenter.nordicsemi.com/pdf/nRF52820_OPS_v0.7.pdf?src-supplier=Digikey; ComponentLink1URL: https://infocenter.nordicsemi.com/pdf/nRF52820_OPS_v0.7.pdf?src-supplier=Digikey; Manufacturer Part Number 1: NRF52820-QDAA-R; Pricing: 1=2,82, 100=2,41, 500=2,09, 1000=1,91001 (USD); RoHS: No; Stock: 39863; Supplier 1: Digikey; Supplier Part Number 1: 4823-NRF52820-QDAA-RCT-ND; Library Name: fast_charger_slide_controller_P2.SchLib
U5 = BMI323: IC - IMU Accel/gyro I2C/SPI 14LGA; Manufacturer 1: Bosch Tools; .Mounting: SMT; Category: Linear ICs; Component Kind: Standard; Manufacturer Part Number 1: BMI323; Pricing: 1=4,52, 10=3,387, 25=3,0104, 50=2,8598, 100=2,7846, 500=2,33306, 1000=2,18254 (USD); RoHS: No; Stock: 4795; Supplier 1: Digikey; Supplier Part Number 1: 828-BMI323CT-ND; Library Name: fast_charger_slide_controller_P2.SchLib
U6 = AP2280-2WG-7: IC - Load Switch Power 105 mOhm 2A Single Channel SOT-25; Manufacturer 1: Diodes; .Mounting: SMT; .PCBChecked: Lucas Vieira; Interface: On/Off; Max Power Dissipation: 750mW; Number of Outputs: 1; On-state Resistance-Max: 105MR; Output Configuration: High Side; Output Current: 0A; Voltage: 6V; Case/Package: SOT-25; Category: Hot Swap Controllers; Component Kind: Standard; ComponentLink1Description: https://datasheet.ciiva.com/15109/getdatasheetpartid-496102-15109123.pdf?src-supplier=Verical; ComponentLink1URL: https://datasheet.ciiva.com/15109/getdatasheetpartid-496102-15109123.pdf?src-supplier=Verical; ComponentLink2Description: https://datasheet.ciiva.com/3232/ap2280-3232583.pdf?src-supplier=Avnet; ComponentLink2URL: https://datasheet.ciiva.com/3232/ap2280-3232583.pdf?src-supplier=Avnet; ComponentLink3Description: https://datasheet.ciiva.com/1962/ap2280-1962200.pdf?src-supplier=Digikey; ComponentLink3URL: https://datasheet.ciiva.com/1962/ap2280-1962200.pdf?src-supplier=Digikey; ComponentLink4Description: https://datasheet.ciiva.com/1962/ap2280-1962201.pdf?src-supplier=Digikey; ComponentLink4URL: https://datasheet.ciiva.com/1962/ap2280-1962201.pdf?src-supplier=Digikey; ComponentLink5Description: https://datasheet.ciiva.com/1962/ap2280-1962202.pdf?src-supplier=Digikey; ComponentLink5URL: https://datasheet.ciiva.com/1962/ap2280-1962202.pdf?src-supplier=Digikey; ComponentLink6Description: https://datasheet.ciiva.com/4924/ap2280-4924956.pdf?src-supplier=Mouser; ComponentLink6URL: https://datasheet.ciiva.com/4924/ap2280-4924956.pdf?src-supplier=Mouser; ComponentLink7Description: https://datasheet.ciiva.com/16415/ap2280-16415656.pdf?src-supplier=Future+Electronics; ComponentLink7URL: https://datasheet.ciiva.com/16415/ap2280-16415656.pdf?src-supplier=Future+Electronics; Current: 2A; Height: 1.3mm; Lead Free: Lead Free; Length: 3.1mm; Manufacturer 2: Diodes; Manufacturer 3: Diodes; Manufacturer Part Number 1: AP2280-2WG-7; Manufacturer Part Number 2: AP2280-1WG-7; Manufacturer Part Number 3: AP22804AW5-7; Max Current Rating: 2A; Max Operating Temperature: 85°C; Max Output current: 2A; Min Operating Temperature: -40°C; Mounting Technology: Surface Mount; Package Quantity: 3000; Packaging: Tape and Reel; Pins: 5; Power Dissipation: 750mW; Pricing: 1=0,64, 10=0,56, 25=0,526, 100=0,4293, 250=0,39876, 500=0,33936, 1000=0,27149 (USD); Radiation Hardening: No; Reach SVHC Compliant: Yes; RoHS: Yes; RoHS Compliant: Yes; Series: -; Stock: 270764; Supplier 1: Digikey; Supplier Part Number 1: AP2280-2WGDICT-ND; Weight: 0.001058oz; Width: 1.7mm; .SCHChecked: Lucas Vieira; Library Name: fast_charger_slide_controller_P2.SchLib
Y1 = XRCGB32M000F2P01R0: CRYSTAL - 32MHz 8pF 100Ohm 4-Pin.; Manufacturer 1: Murata; .Mounting: SMT; .PCBChecked: Lucas Vieira; Category 1: Crystals; Description 1: Crystal 32MHz ±20ppm (Tol) ±20ppm (Stability) 8pF 100Ohm 4-Pin SMD T/R; Component Kind: Standard; Manufacturer 2: ECS Inc.; Manufacturer 3: ECS Inc.; Manufacturer Part Number 1: XRCGB32M000F2P01R0; Manufacturer Part Number 2: ECS-320-8-37B-CKY-TR; Manufacturer Part Number 3: ECS-320-8-37-CKM-TR3; Pricing 1: 1=0,22, 10=0,183, 50=0,1648, 100=0,1465, 500=0,13922, 1000=0,129 (USD); RoHS 1: Yes; RoHS Compliant: Yes; Stock 1: 3710789; Supplier 1: Digikey; Supplier Part Number 1: 490-18340-1-ND; .SCHCHecked: Lucas Vieira; Library Name: fast_charger_slide_controller_P2.SchLib
Y2 = ECS-.327-9-34B-TR: CRYSTAL - 32.768kHz 9pF 2-Pin 2-SMD; Manufacturer 1: ECS International; .Mounting: SMT; .PCBChecked: Lucas Vieira; Category 1: Crystals; Description 1: Crystal 0.032768MHz ±20ppm (Tol) 9pF Flexural 70000Ohm 2-Pin Ultra Mini-CSMD T/R; ESR (Equivalent Series Resistance): 70kR; Frequency Stability: 20ppm; Case/Package: SMD/SMT; Component Kind: Standard; ComponentLink1Description: https://datasheet.ciiva.com/15047/ecx-31b-15047050.pdf?src-supplier=Digikey; ComponentLink1URL: https://datasheet.ciiva.com/15047/ecx-31b-15047050.pdf?src-supplier=Digikey; ComponentLink2Description: https://datasheet.ciiva.com/15047/ecx-31b-15047051.pdf?src-supplier=Digikey; ComponentLink2URL: https://datasheet.ciiva.com/15047/ecx-31b-15047051.pdf?src-supplier=Digikey; ComponentLink3Description: https://datasheet.ciiva.com/15047/ecx-31b-15047052.pdf?src-supplier=Digikey; ComponentLink3URL: https://datasheet.ciiva.com/15047/ecx-31b-15047052.pdf?src-supplier=Digikey; Frequency: 32.768kHz; Frequency Tolerance: 20ppm; Height: 0.035inch; Lead Pitch: 2.4mm; Length: 0.126inch; Load Capacitance: 9pF; Manufacturer 2: EPSON; Manufacturer 3: Citizen Finedevice Co Ltd; Manufacturer Part Number 1: ECS-.327-9-34B-TR; Manufacturer Part Number 2: FC-135 32.7680KA-AC0; Manufacturer Part Number 3: CM315D32768DZCT; Max Operating Temperature: 85°C; Min Operating Temperature: -40°C; Mounting Technology: Surface Mount; Package Quantity: 3000; Packaging: Tape and Reel; Pricing 1: 1=0,46, 10=0,382, 50=0,344, 100=0,3058, 500=0,29056, 1000=0,24468 (USD); RoHS 1: Yes; RoHS Compliant: Yes; Series: ECX-31B; Stock 1: 109782; Supplier 1: Digikey; Supplier Part Number 1: 50-ECS-.327-9-34B-TRCT-ND; Termination: SMD/SMT; Width: 1.5mm; .SCHChecked: Lucas Vieira; Library Name: fast_charger_slide_controller_P2.SchLib
